# Altium schematic sheet FRAM, MRAM.SchDoc("FRAM, MRAM")
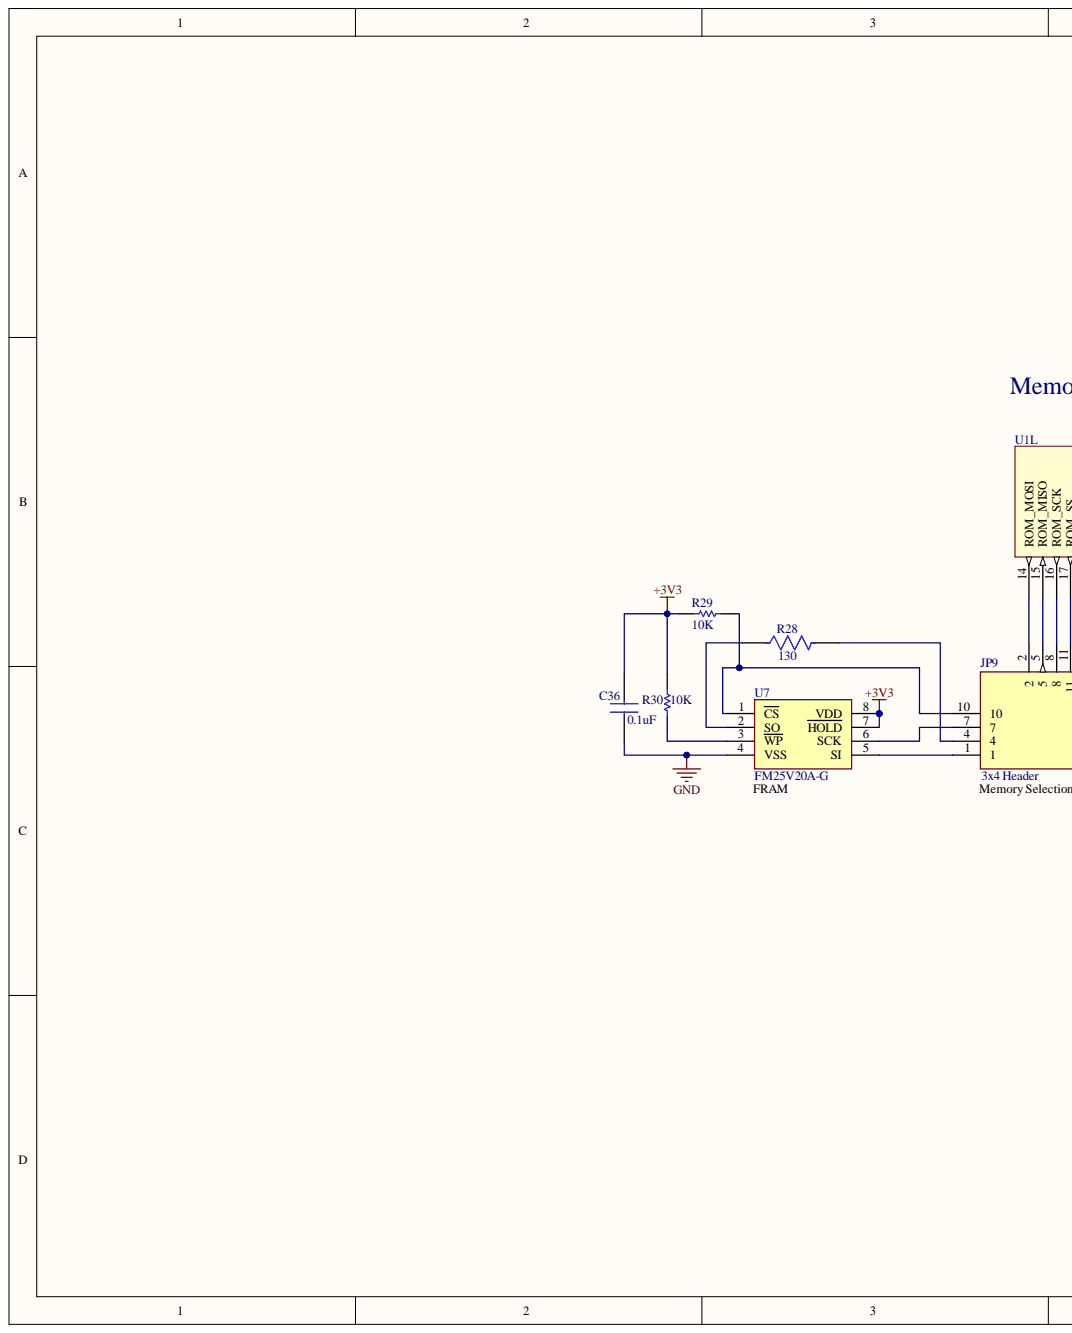
[diagram p0: FRAM, MRAM.SchDoc, left]
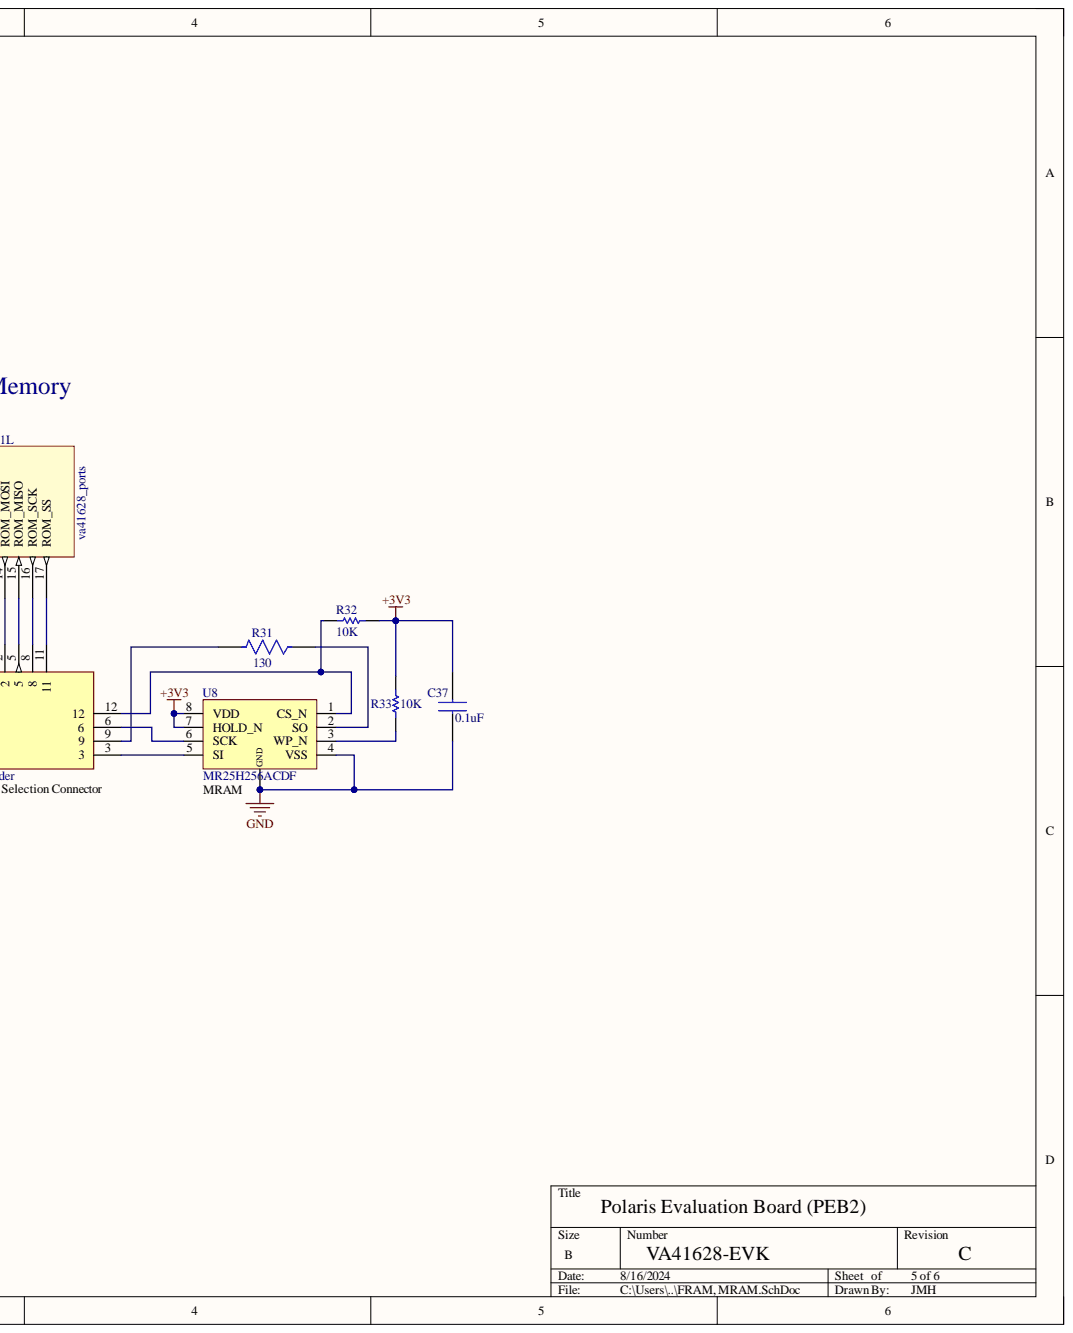
[diagram p1: FRAM, MRAM.SchDoc, right]

## Parts
C36  0.1uF  [C0603C104K3RAC7013]
C37  0.1uF  [C0603C104K3RAC7013]
JP9  3x4 Header  [TSW-104-07-G-T]
R28  130  [CRCW0603130RFKEAC]
R29  10k  [CRCW060310K0FKEAC]
R30  10k  [CRCW060310K0FKEAC]
R31  130  [CRCW0603130RFKEAC]
R32  10k  [CRCW060310K0FKEAC]
R33  10k  [CRCW060310K0FKEAC]
U1  va41628_ports  [TCQFP-128-0.40-36-C]  (part L)
U7  FM25V20A-G  [FM25V20A-G]
U8  MR25H256ACDF  [MR25H256ACDF_MR25H256ACDF(Primary)]

## Nets
+3V3: C36.1 C37.1 R29.1 R30.1 R32.1 R33.1 U7.7(HOLD) U7.8(VDD) U8.7(HOLD_N) U8.8(VDD)
GND: C36.2 C37.2 U7.4(VSS) U8.4(VSS) U8.GND
NetJP9_1: JP9.1 U7.5(SI)
NetJP9_2: JP9.2 U1.14(ROM_MOSI)
NetJP9_3: JP9.3 U8.5(SI)
NetJP9_4: JP9.4 R28.2
NetJP9_5: JP9.5 U1.15(ROM_MISO)
NetJP9_6: JP9.6 U8.6(SCK)
NetJP9_7: JP9.7 U7.6(SCK)
NetJP9_8: JP9.8 U1.16(ROM_SCK)
NetJP9_9: JP9.9 R31.2
NetJP9_10: JP9.10 R29.2 U7.1(CS)
NetJP9_11: JP9.11 U1.17(ROM_SS)
NetJP9_12: JP9.12 R32.2 U8.1(CS_N)
NetR28_1: R28.1 U7.2(SO)
NetR30_2: R30.2 U7.3(WP)
NetR31_1: R31.1 U8.2(SO)
NetR33_2: R33.2 U8.3(WP_N)

## Library data of the parts
C36 C37 = C0603C104K3RAC7013: Capacitor; Manufacturer_Name: KEMET; Component Kind: Standard; Datasheet Link: https://www.kemet.com/specsheet/C0603C104K3RACTM; Height: 0.95mm; Library Name: SamacSys.SchLib; Manufacturer_Part_Number: C0603C104K3RAC7013; Parameter 1: 0.1uF
JP9 = TSW-104-07-T-T: Connector; Manufacturer_Name: SAMTEC; Arrow Part Number: TSW-104-07-T-T; Arrow Price/Stock: https://www.arrow.com/en/products/tsw-104-07-t-t/samtec; Component Kind: Standard; Datasheet Link: http://suddendocs.samtec.com/catalog_english/tsw_th.pdf; Height: 8.38mm; Library Name: SamacSys.SchLib; Manufacturer_Part_Number: TSW-104-07-T-T; Mouser Part Number: 200-TSW10407TT; Mouser Price/Stock: https://www.mouser.co.uk/ProductDetail/Samtec/TSW-104-07-T-T?qs=rU5fayqh%252BE0u%252BKr0FLyE%2FQ%3D%3D
R28 R31 = CMP-2000-03889-2: RES Thick Film, 130Ω, 1%, 0.1W, 100ppm/°C, 0603; Max Power Dissipation: 100mW; Voltage Rating: 75V; Case Code (Imperial): 0603; Case Code (Metric): 1608; Case/Package: 0603; Component Kind: Standard; Composition: Thick Film; Contact Plating: Tin; Depth: 0.85mm; Features: Automotive AEC-Q200; Height: 0.45mm; Lead Free: Lead Free; Length: 1.55mm; Library Name: Altium Content Vault; Max Operating Temperature: 155°C; Military Standard: Not; Min Operating Temperature: -55°C; Number of Pins: 2; Number of Terminations: 2; Package Quantity: 10; Packaging: Tape and Reel; Power Rating: 100mW; Radiation Hardening: No; Resistance: 130; Temperature Coefficient: 100ppm/°C; Termination: SMD/SMT; Tolerance: 1%; Voltage Rating (DC): 75V; Weight: 7.1E-05oz; Width: 0.85mm; Working Voltage: 75V
R29 R30 R32 R33 = CMP-1666-00005-4: Chip Resistor, 10 KOhm, +/- 1%, 100 mW, -55 to 155 degC, 0603 (1608 Metric), RoHS, Tape and Reel; Manufacturer: Vishay Semiconductor; Voltage Rating: 75 V; Component Kind: Standard; ComponentLink1Description: Manufacturer URL; ComponentLink1URL: http://www.vishay.com/; ComponentLink2Description: Datasheet; ComponentLink2URL: https://datasheet.ciiva.com/11809/0900766b80d98afc-11809037.pdf; DatasheetVersion: 06/2012; Height: 0.45 mm; Length: 1.55 mm; Library Name: Altium Content Vault; Max Operating Temperature: 155 degC; Min Operating Temperature: -55 degC; Mounting Technology: Surface Mount; Package Quantity: 5000; PackageDescription: 2-Pin Surface Mount Device, Body 1.575 x 0.85 mm; PackageReference: 0603; Packaging: Tape and Reel; Parameter 1: 10K; REACH SVHC: Unknown; RoHSCompliant: true
U1 = va41628_ports: Polaris Lite 128 pin; Component Kind: Standard; Library Name: va416xx.SchLib
U7 = FM25V20A-G: Integrated Circuit; Manufacturer_Name: Cypress Semiconductor; Arrow Part Number: FM25V20A-G; Arrow Price/Stock: https://www.arrow.com/en/products/fm25v20a-g/cypress-semiconductor; Component Kind: Standard; Datasheet Link: https://eu.mouser.com/datasheet/2/100/001-90261_FM25V20A_2_Mbit_256_K_8_Serial_SPI_F_RAM-748798.pdf; Height: 2.03mm; Manufacturer_Part_Number: FM25V20A-G; Mouser Part Number: 727-FM25V20A-G; Mouser Price/Stock: https://www.mouser.co.uk/ProductDetail/Cypress-Semiconductor/FM25V20A-G?qs=iCT64eccu7g0AE%252B39Asm9A%3D%3D
U8 = CMP-019-00049-2: NVRAM MRAM Serial-SPI 256Kbit 2.7V to 3.6V 8-Pin DFN Tray; Frequency: 40MHz; Memory Size: 256Kb; Memory Type: Non-Volatile,RAM; Case/Package: DFN; Component Kind: Standard; Max Operating Temperature: 85°C; Min Operating Temperature: -40°C; Mount: Surface Mount; Packaging: Tray; RoHS Compliant: Yes; Series: -; Library Name: Vorago Tech
